# Altium schematic sheet InfoDisplay.SchDoc
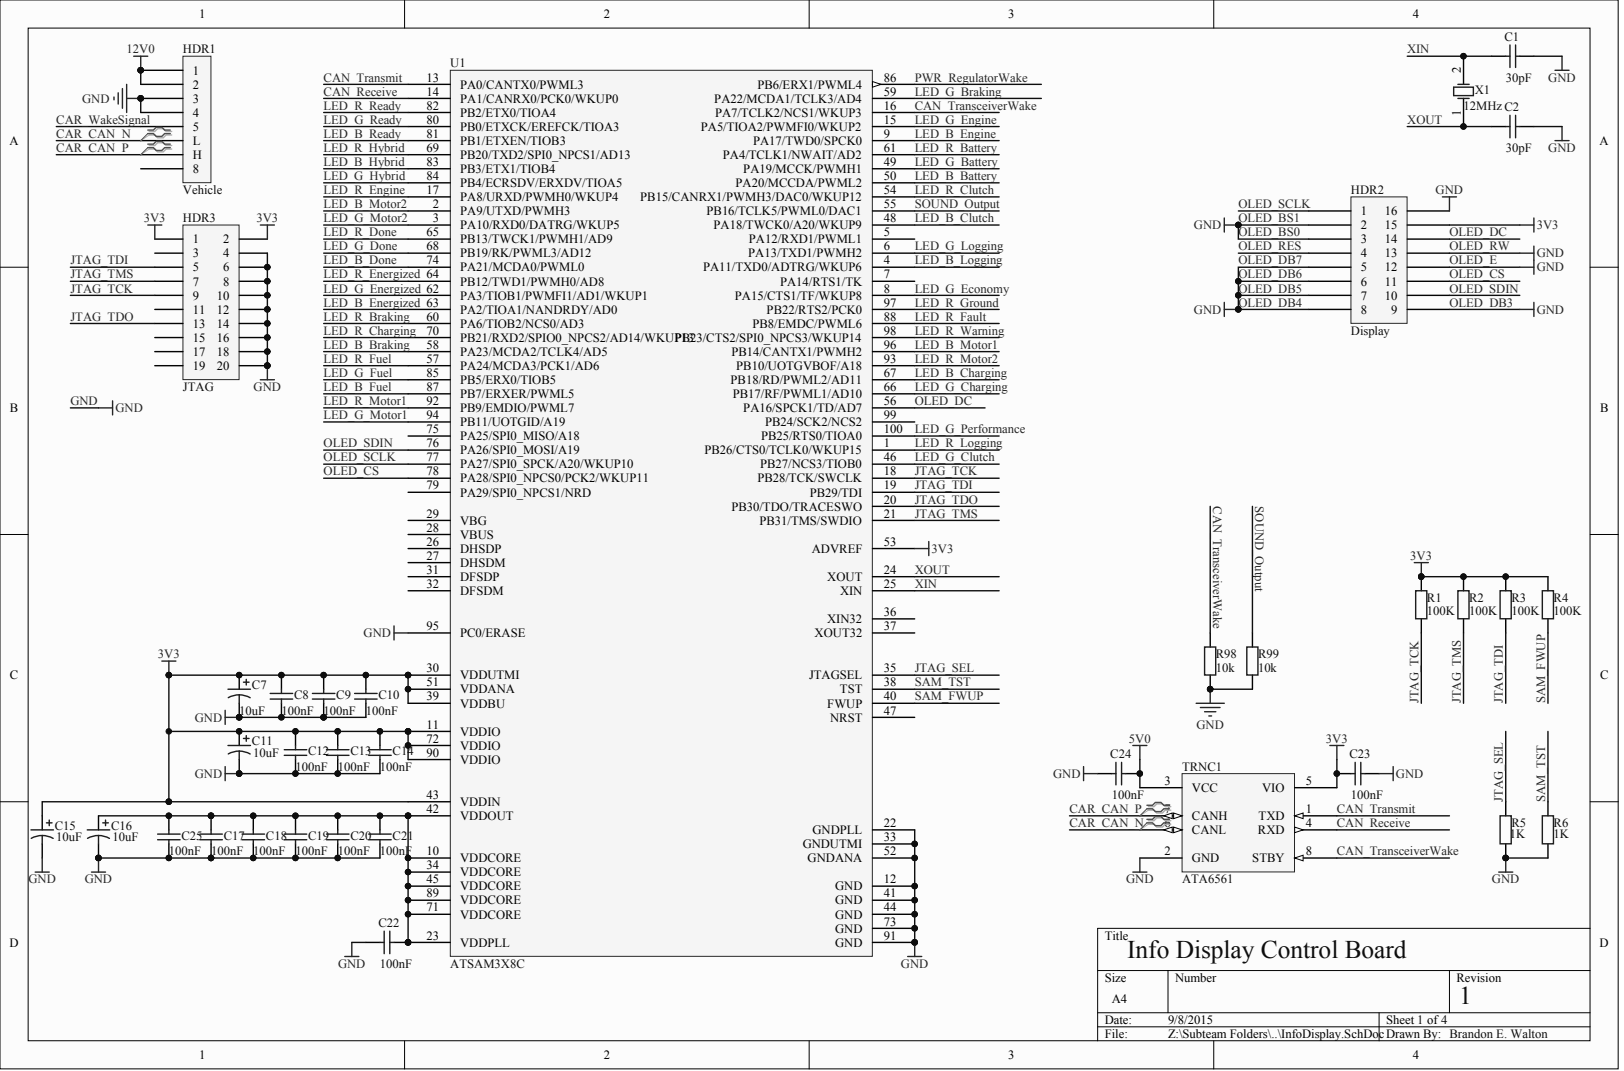
[diagram p0: InfoDisplay.SchDoc]

## Parts
C1  value 30pF  (hidden comment: CL10C300FB8NNNC)
C2  value 30pF  (hidden comment: Cap)
C7  value 10uF  (hidden comment: Cap Pol1)
C8  value 100nF  (hidden comment: Cap)
C9  value 100nF  (hidden comment: Cap)
C10  value 100nF  (hidden comment: Cap)
C11  value 10uF  (hidden comment: Cap Pol1)
C12  value 100nF  (hidden comment: Cap)
C13  value 100nF  (hidden comment: Cap)
C14  value 100nF  (hidden comment: Cap)
C15  value 10uF  (hidden comment: Cap Pol1)
C16  value 10uF  (hidden comment: Cap Pol1)
C17  value 100nF  (hidden comment: Cap)
C18  value 100nF  (hidden comment: Cap)
C19  value 100nF  (hidden comment: Cap)
C20  value 100nF  (hidden comment: Cap)
C21  value 100nF  (hidden comment: Cap)
C22  value 100nF  (hidden comment: Cap)
C23  value 100nF  (hidden comment: Cap)
C24  value 100nF  (hidden comment: Cap)
C25  value 100nF  (hidden comment: Cap)
HDR1  Vehicle
HDR2  Display
HDR3  JTAG
R1  value 100K  (hidden comment: Res2)
R2  value 100K  (hidden comment: Res2)
R3  value 100K  (hidden comment: Res2)
R4  value 100K  (hidden comment: Res2)
R5  value 1K  (hidden comment: Res2)
R6  value 1K  (hidden comment: Res2)
R98  value 10k  (hidden comment: RC0603JR-0710KL)
R99  value 10k  (hidden comment: 311-10KGRCT-ND)
TRNC1  ATA6561
U1  ATSAM3X8C
X1  12MHz

## Nets
3V3: C7.1 C8.1 C9.1 C10.1 C11.1 C12.1 C13.1 C14.1 C15.1 C23.2 HDR2.15 HDR3.1 HDR3.2 R1.2 R2.2 R3.2 R4.2 TRNC1.5(VIO) U1.11(VDDIO) U1.30(VDDUTMI) U1.39(VDDBU) U1.43(VDDIN) U1.51(VDDANA) U1.53(ADVREF) U1.72(VDDIO) U1.90(VDDIO)
5V0: C24.1 TRNC1.3(VCC)
12V0: HDR1.A1 HDR1.A2
CAN_RECEIVE: TRNC1.4(RXD) U1.14(PA1/CANRX0/PCK0/WKUP0)
CAN_TRANSCEIVERWAKE: R98.2 TRNC1.8(STBY) U1.16(PA7/TCLK2/NCS1/WKUP3)
CAN_TRANSMIT: TRNC1.1(TXD) U1.13(PA0/CANTX0/PWML3)
CAR_CAN_N: HDR1.A6(L) TRNC1.6(CANL)
CAR_CAN_P: HDR1.A7(H) TRNC1.7(CANH)
CAR_WAKESIGNAL: HDR1.A5
GND: C1.1 C2.1 C7.2 C8.2 C9.2 C10.2 C11.2 C12.2 C13.2 C14.2 C15.2 C16.2 C17.2 C18.2 C19.2 C20.2 C21.2 C22.2 C23.1 C24.2 C25.2 HDR1.A3 HDR1.A4 HDR2.2 HDR2.3 HDR2.5 HDR2.6 HDR2.7 HDR2.8 HDR2.9 HDR2.12 HDR2.13 HDR2.16 HDR3.4 HDR3.6 HDR3.8 HDR3.10 HDR3.12 HDR3.14 HDR3.16 HDR3.18 HDR3.20 R5.1 R6.1 R98.1 R99.1 TRNC1.2(GND) U1.12(GND) U1.22(GNDPLL) U1.33(GNDUTMI) U1.41(GND) U1.44(GND) U1.52(GNDANA) U1.73(GND) U1.91(GND) U1.95(PC0/ERASE)
JTAG_SEL: R5.2 U1.35(JTAGSEL)
JTAG_TCK: HDR3.9 R1.1 U1.18(PB28/TCK/SWCLK)
JTAG_TDI: HDR3.5 R3.1 U1.19(PB29/TDI)
JTAG_TDO: HDR3.13 U1.20(PB30/TDO/TRACESWO)
JTAG_TMS: HDR3.7 R2.1 U1.21(PB31/TMS/SWDIO)
LED_B_BATTERY: U1.50(PA20/MCCDA/PWML2)
LED_B_BRAKING: U1.58(PA23/MCDA2/TCLK4/AD5)
LED_B_CHARGING: U1.67(PB18/RD/PWML2/AD11)
LED_B_CLUTCH: U1.48(PA18/TWCK0/A20/WKUP9)
LED_B_DONE: U1.74(PA21/MCDA0/PWML0)
LED_B_ENERGIZED: U1.63(PA2/TIOA1/NANDRDY/AD0)
LED_B_ENGINE: U1.9(PA17/TWD0/SPCK0)
LED_B_FUEL: U1.87(PB7/ERXER/PWML5)
LED_B_HYBRID: U1.83(PB3/ETX1/TIOB4)
LED_B_LOGGING: U1.4(PA11/TXD0/ADTRG/WKUP6)
LED_B_MOTOR1: U1.96(PB14/CANTX1/PWMH2)
LED_B_MOTOR2: U1.2(PA9/UTXD/PWMH3)
LED_B_READY: U1.81(PB1/ETXEN/TIOB3)
LED_G_BATTERY: U1.49(PA19/MCCK/PWMH1)
LED_G_BRAKING: U1.59(PA22/MCDA1/TCLK3/AD4)
LED_G_CHARGING: U1.66(PB17/RF/PWML1/AD10)
LED_G_CLUTCH: U1.46(PB27/NCS3/TIOB0)
LED_G_DONE: U1.68(PB19/RK/PWML3/AD12)
LED_G_ECONOMY: U1.8(PA15/CTS1/TF/WKUP8)
LED_G_ENERGIZED: U1.62(PA3/TIOB1/PWMFI1/AD1/WKUP1)
LED_G_ENGINE: U1.15(PA5/TIOA2/PWMFI0/WKUP2)
LED_G_FUEL: U1.85(PB5/ERX0/TIOB5)
LED_G_HYBRID: U1.84(PB4/ECRSDV/ERXDV/TIOA5)
LED_G_LOGGING: U1.6(PA13/TXD1/PWMH2)
LED_G_MOTOR1: U1.94(PB11/UOTGID/A19)
LED_G_MOTOR2: U1.3(PA10/RXD0/DATRG/WKUP5)
LED_G_PERFORMANCE: U1.100(PB25/RTS0/TIOA0)
LED_G_READY: U1.80(PB0/ETXCK/EREFCK/TIOA3)
LED_R_BATTERY: U1.61(PA4/TCLK1/NWAIT/AD2)
LED_R_BRAKING: U1.60(PA6/TIOB2/NCS0/AD3)
LED_R_CHARGING: U1.70(PB21/RXD2/SPIO0_NPCS2/AD14/WKUP13)
LED_R_CLUTCH: U1.54(PB15/CANRX1/PWMH3/DAC0/WKUP12)
LED_R_DONE: U1.65(PB13/TWCK1/PWMH1/AD9)
LED_R_ENERGIZED: U1.64(PB12/TWD1/PWMH0/AD8)
LED_R_ENGINE: U1.17(PA8/URXD/PWMH0/WKUP4)
LED_R_FAULT: U1.88(PB8/EMDC/PWML6)
LED_R_FUEL: U1.57(PA24/MCDA3/PCK1/AD6)
LED_R_GROUND: U1.97(PB22/RTS2/PCK0)
LED_R_HYBRID: U1.69(PB20/TXD2/SPI0_NPCS1/AD13)
LED_R_LOGGING: U1.1(PB26/CTS0/TCLK0/WKUP15)
LED_R_MOTOR1: U1.92(PB9/EMDIO/PWML7)
LED_R_MOTOR2: U1.93(PB10/UOTGVBOF/A18)
LED_R_READY: U1.82(PB2/ETX0/TIOA4)
LED_R_WARNING: U1.98(PB23/CTS2/SPI0_NPCS3/WKUP14)
OLED_CS: HDR2.11 U1.78(PA28/SPI0_NPCS0/PCK2/WKUP11)
OLED_DC: HDR2.14 U1.56(PA16/SPCK1/TD/AD7)
OLED_RES: HDR2.4
OLED_SCLK: HDR2.1 U1.77(PA27/SPI0_SPCK/A20/WKUP10)
OLED_SDIN: HDR2.10 U1.76(PA26/SPI0_MOSI/A19)
PWR_REGULATORWAKE: U1.86(PB6/ERX1/PWML4)
SAM_FWUP: R4.1 U1.40(FWUP)
SAM_TST: R6.2 U1.38(TST)
SOUND_OUTPUT: R99.2 U1.55(PB16/TCLK5/PWML0/DAC1)
XIN: C1.2 U1.25(XIN) X1.2
XOUT: C2.2 U1.24(XOUT) X1.1
NetC16_1: C16.1 C17.1 C18.1 C19.1 C20.1 C21.1 C22.1 C25.1 U1.10(VDDCORE) U1.23(VDDPLL) U1.34(VDDCORE) U1.42(VDDOUT) U1.45(VDDCORE) U1.71(VDDCORE) U1.89(VDDCORE)

Not connected: HDR1 A8; HDR3 3 11 15 17 19; U1 5(PA12/RXD1/PWML1) 7(PA14/RTS1/TK) 26(DHSDP) 27(DHSDM) 28(VBUS) 29(VBG) 31(DFSDP) 32(DFSDM) 36(XIN32) 37(XOUT32) 47(NRST) 75(PA25/SPI0_MISO/A18) 79(PA29/SPI0_NPCS1/NRD) 99(PB24/SCK2/NCS2)

## Library data of the parts
C2 = (no symbol name): PartNumber: CL10C300FB8NNNC; Published: 8-Jun-2000; LatestRevisionDate: 17-Jul-2002; LatestRevisionNote: Re-released for DXP Platform.; PackageReference: RAD-0.3; PackageDescription: Radial Cap, Thru-Hole; 2 Leads; 0.3 in Pin Spacing; Digikey: 1276-2259-1-ND; Manufacturer PartNo: CL10C300FB8NNNC; Publisher: Altium Limited
C8-C10 C12-C14 C18-C23 C25 = (no symbol name): Partnumber: GRM188R71E104KA01D; Published: 8-Jun-2000; LatestRevisionDate: 17-Jul-2002; LatestRevisionNote: Re-released for DXP Platform.; PackageReference: RAD-0.3; PackageDescription: Radial Cap, Thru-Hole; 2 Leads; 0.3 in Pin Spacing; Digikey: 490-1524-1-ND; Publisher: Altium Limited
C15 = (no symbol name): PartNumber: T491A106K010ZT; Published: 8-Jun-2000; LatestRevisionDate: 17-Jul-2002; LatestRevisionNote: Re-released for DXP Platform.; PackageReference: RB7.6-15; PackageDescription: Polarized Capacitor (Radial); 2 Leads; Digikey: 495-2200-1-ND; Publisher: Altium Limited
C17 = (no symbol name): PartNumber: GRM188R71E104KA01D; Published: 8-Jun-2000; LatestRevisionDate: 17-Jul-2002; LatestRevisionNote: Re-released for DXP Platform.; PackageReference: RAD-0.3; PackageDescription: Radial Cap, Thru-Hole; 2 Leads; 0.3 in Pin Spacing; Digikey: 490-1524-1-ND; Partnumber: GRM188R71E104KA01D; Publisher: Altium Limited
C24 = (no symbol name): Partnumber: GRM188R71E104KA01D; Value: 100nF; Published: 8-Jun-2000; LatestRevisionDate: 17-Jul-2002; LatestRevisionNote: Re-released for DXP Platform.; PackageReference: RAD-0.3; PackageDescription: Radial Cap, Thru-Hole; 2 Leads; 0.3 in Pin Spacing; Digikey: 490-1524-1-ND; Publisher: Altium Limited
R1-R4 = (no symbol name): PartNumber: MCT06030C1003FP500; Published: 8-Jun-2000; LatestRevisionDate: 17-Jul-2002; LatestRevisionNote: Re-released for DXP Platform.; PackageReference: AXIAL-0.4; PackageDescription: Axial Device, Thru-Hole; 2 Leads; 0.4 in Pin Spacing; Digikey: MCT0603-100K-CFCT-ND; Publisher: Altium Limited
R5 R6 = (no symbol name): PartNumber: MCT06030C1001FP500; Published: 8-Jun-2000; LatestRevisionDate: 17-Jul-2002; LatestRevisionNote: Re-released for DXP Platform.; PackageReference: AXIAL-0.4; PackageDescription: Axial Device, Thru-Hole; 2 Leads; 0.4 in Pin Spacing; Digikey: MCT0603-1.00K-CFCT-ND; Publisher: Altium Limited
U1 = (no symbol name): PartNumber: ATSAM3X8CA-AU; DigiKey: ATSAM3X8CA-AU-ND
X1 = (no symbol name): PartNumber: ECS-120-20-3X-EN-TR; Published: 8-Jun-2000; LatestRevisionDate: 17-Jul-2002; LatestRevisionNote: Re-released for DXP Platform.; PackageReference: R38; PackageDescription: Crystal, Thru-Hole; 2 Leads; Body 3.1 x 8.2 mm (Dia.xH); Digikey: XC1787CT-ND; Publisher: Altium Limited
C1 C7 C11 C16 HDR1-HDR3 R98 R99 TRNC1 = (no symbol name)
  C1: Published: 8-Jun-2000; LatestRevisionDate: 17-Jul-2002; LatestRevisionNote: Re-released for DXP Platform.; PackageReference: RAD-0.3; PackageDescription: Radial Cap, Thru-Hole; 2 Leads; 0.3 in Pin Spacing; Digikey: 1276-2259-1-ND; PartNumber: CL10C300FB8NNNC
  C7 C11 C16: Published: 8-Jun-2000; LatestRevisionDate: 17-Jul-2002; LatestRevisionNote: Re-released for DXP Platform.; PackageReference: RB7.6-15; PackageDescription: Polarized Capacitor (Radial); 2 Leads; Digikey: 495-2200-1-ND
  HDR3: LatestRevisionDate: 17-Jul-2002; LatestRevisionNote: Re-released for DXP Platform.
  R98 R99: Published: 8-Jun-2000; LatestRevisionDate: 17-Jul-2002; LatestRevisionNote: Re-released for DXP Platform.; PackageReference: AXIAL-0.4; PackageDescription: Axial Device, Thru-Hole; 2 Leads; 0.4 in Pin Spacing; Digikey: 311-10KGRCT-ND
  TRNC1: Digikey: ATA6561; PartNumber: ATA6561
  C1 C7 C11 C16 HDR3 R98 R99: Publisher: Altium Limited
